# Altium schematic sheet POWER_MUXES.SchDoc
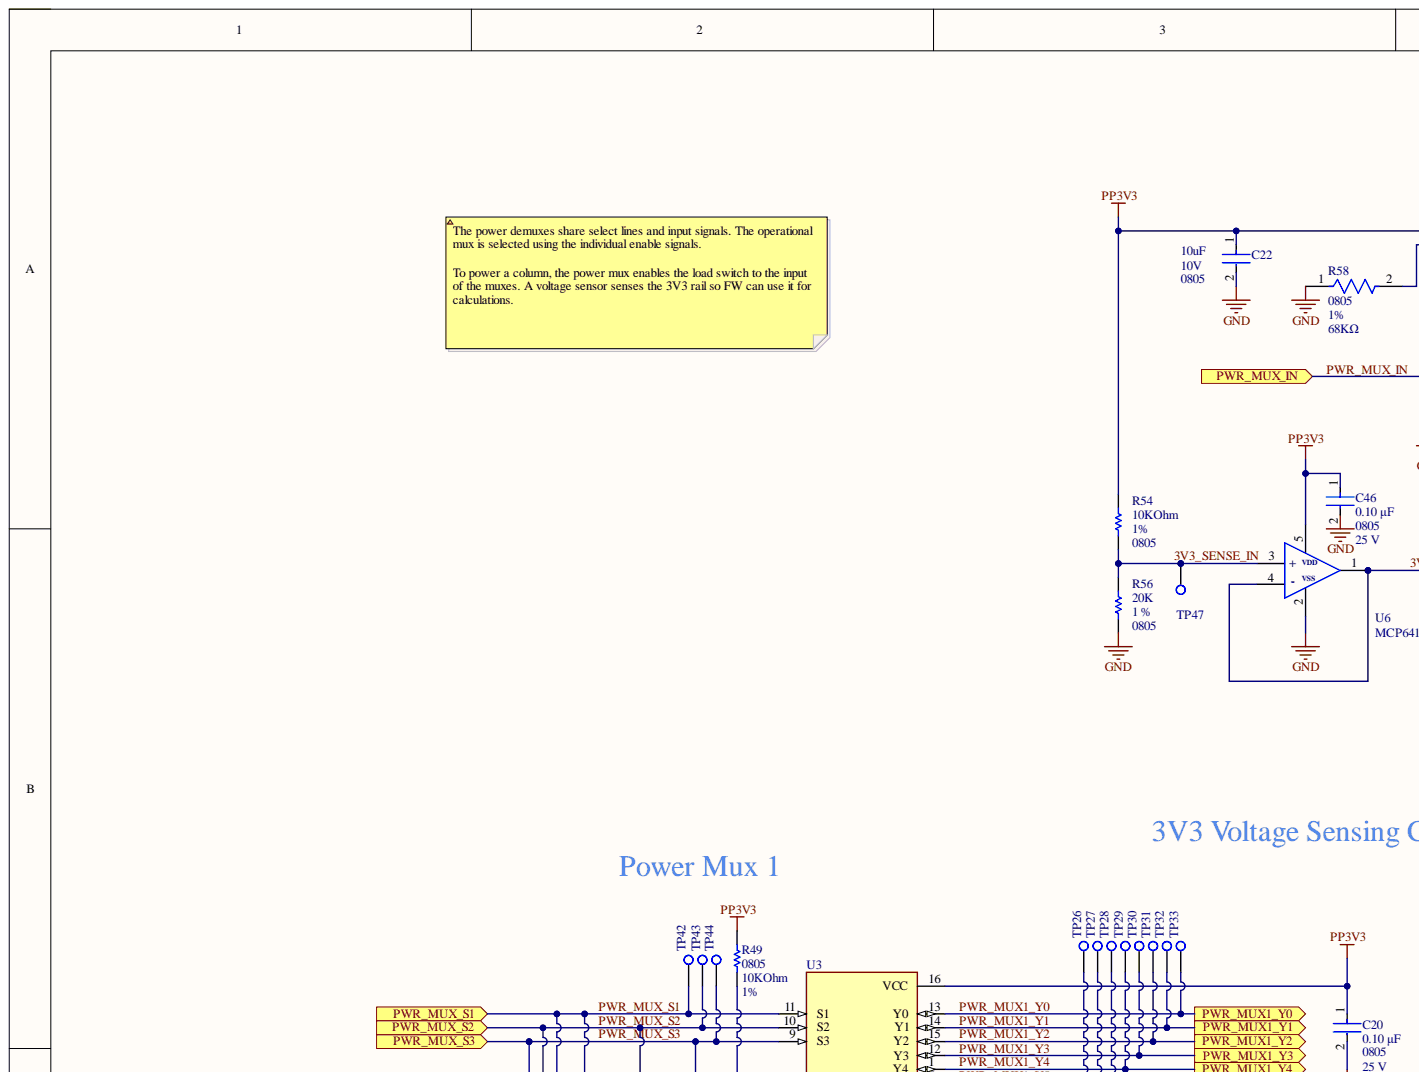
[diagram p0: POWER_MUXES.SchDoc, top left]
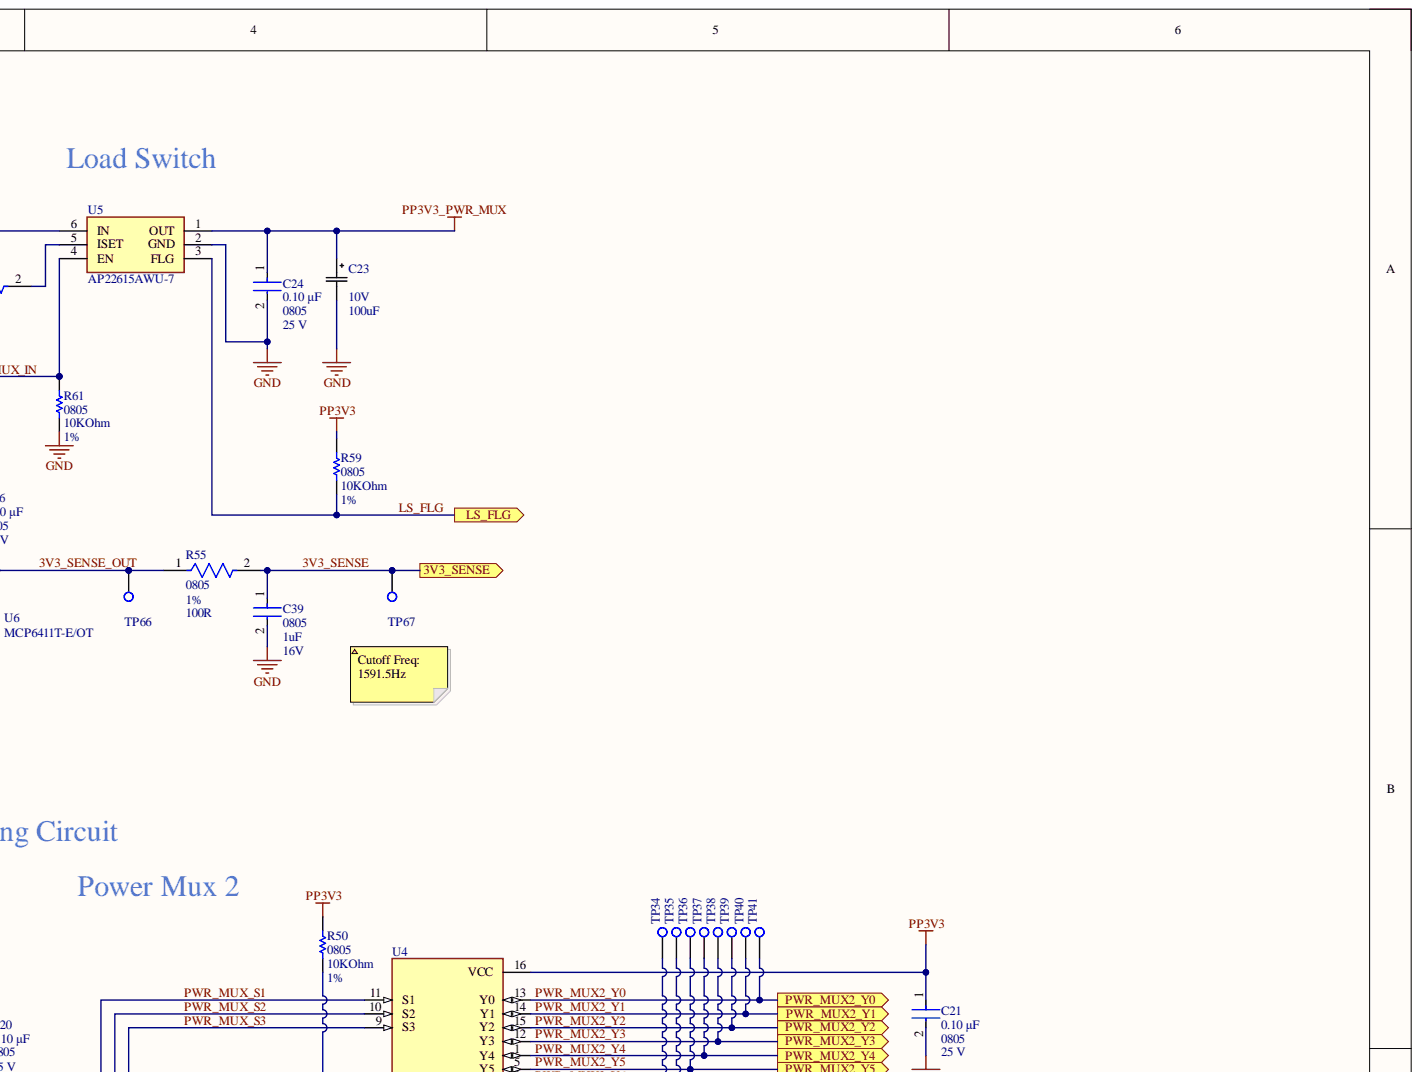
[diagram p1: POWER_MUXES.SchDoc, top right]
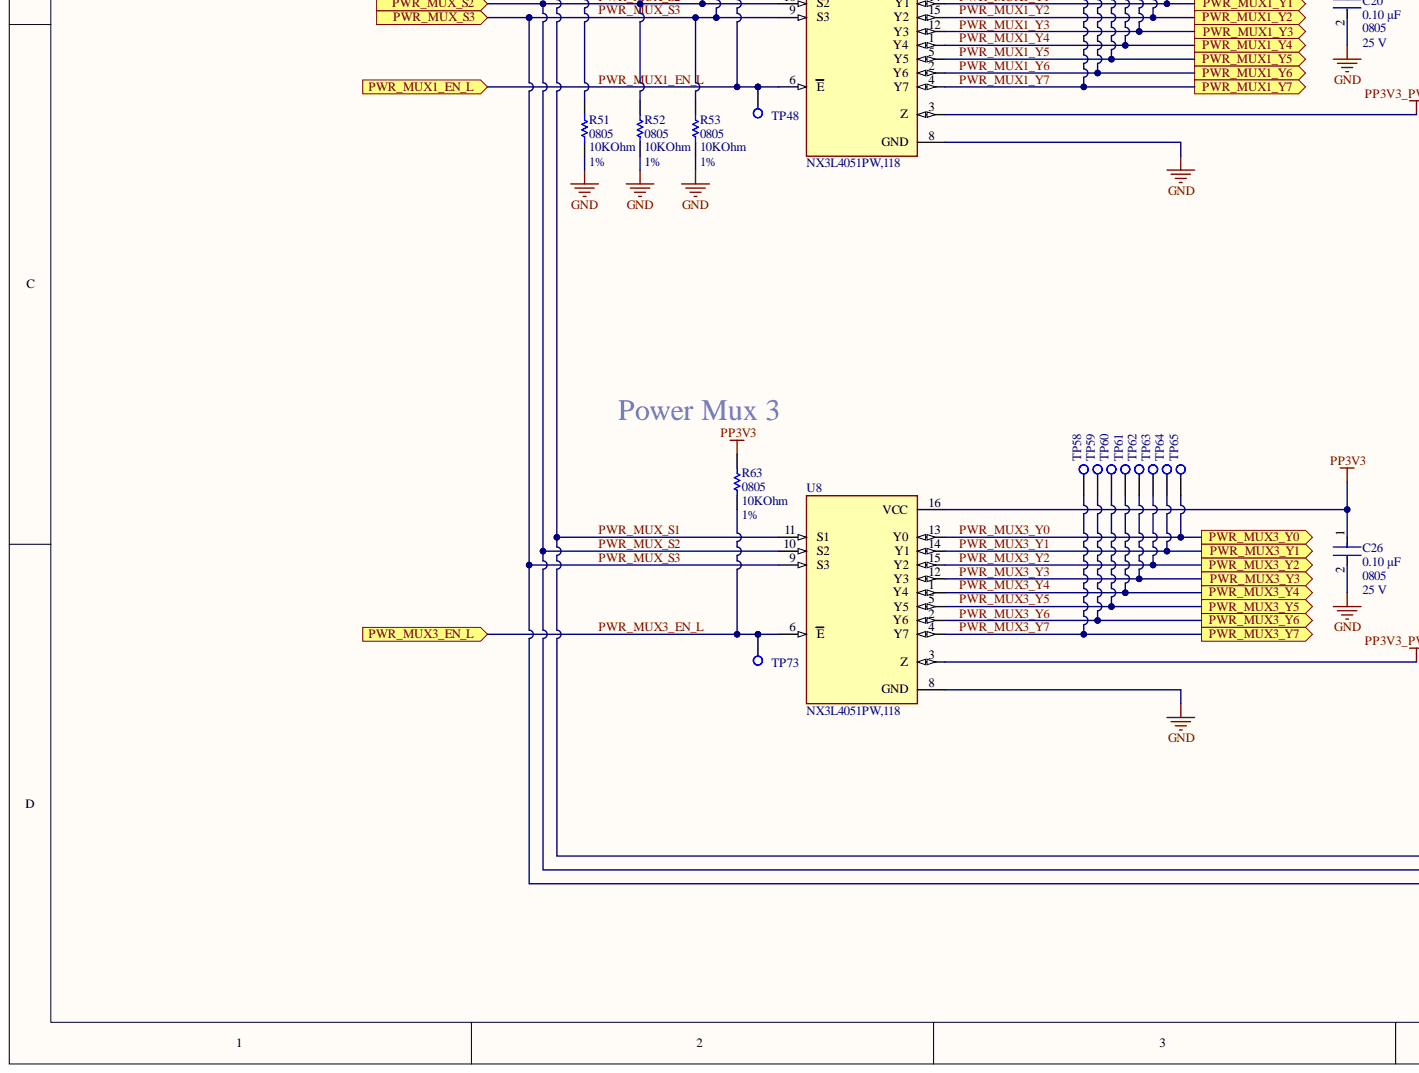
[diagram p2: POWER_MUXES.SchDoc, bottom left]
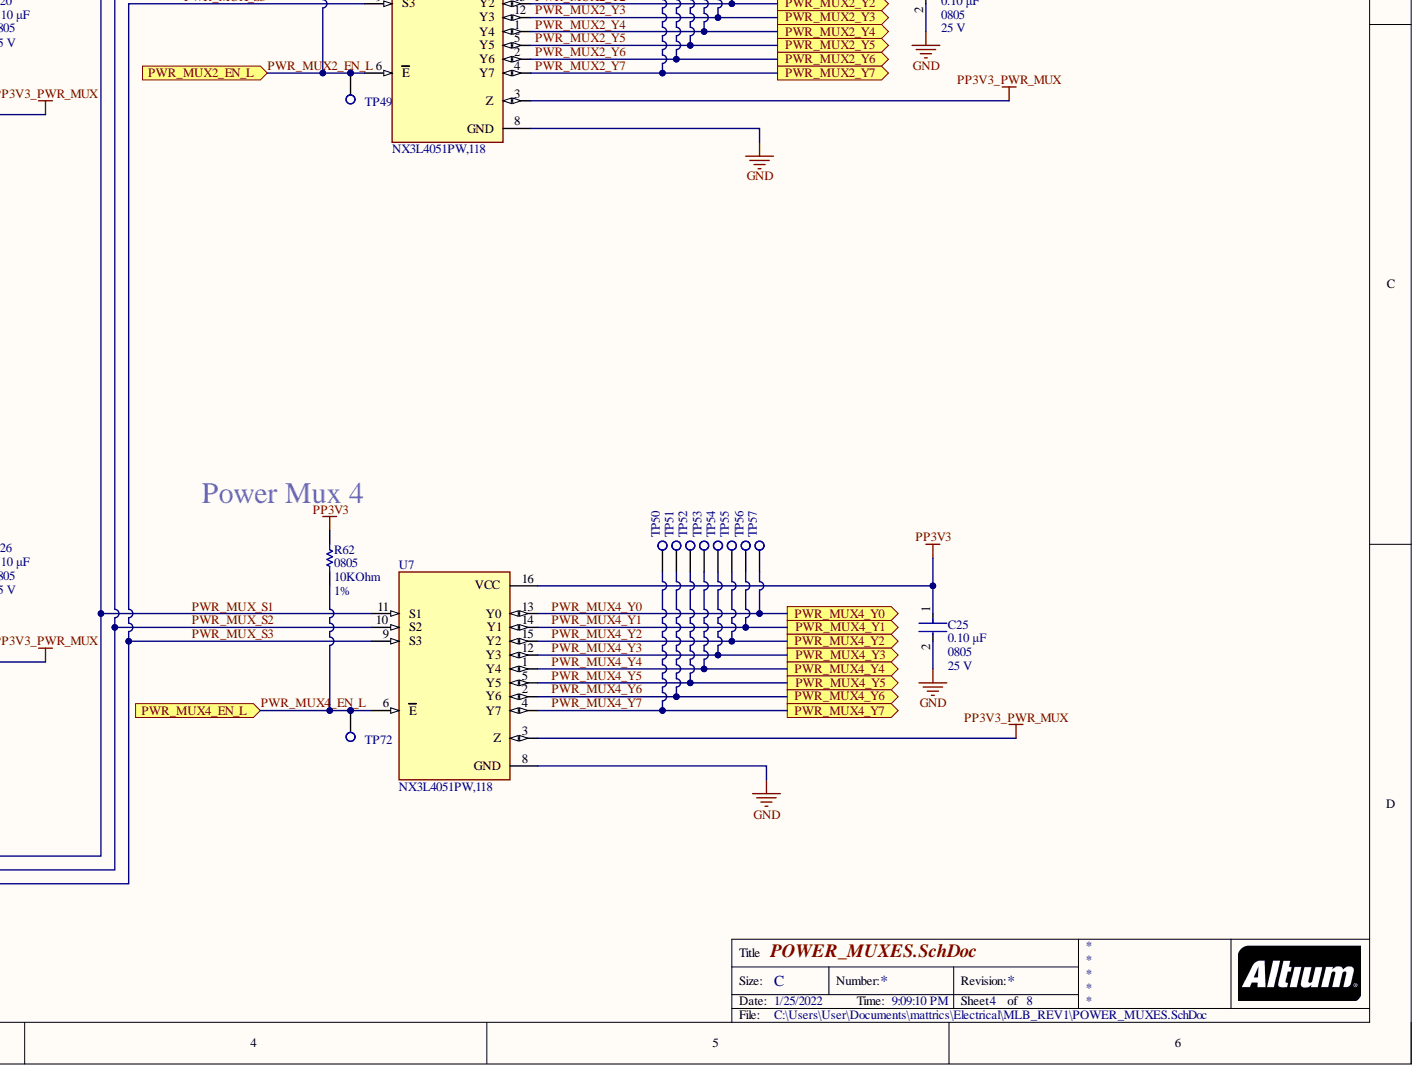
[diagram p3: POWER_MUXES.SchDoc, bottom right]

## Parts
C20  value 0.10 μF  Capacitance: 0.10 μF  Package: 0805  Voltage Rating: 25 V  [FP-C0805C-DN-MFG]  (hidden comment: C0805C104M3RACTU)
C21  value 0.10 μF  Capacitance: 0.10 μF  Package: 0805  Voltage Rating: 25 V  [FP-C0805C-DN-MFG]  (hidden comment: C0805C104M3RACTU)
C22  Capacitance: 10uF  Case/Package: 0805  Voltage Rating (DC): 10V  [FP-GRM21B-0_15-IPC_A]  (hidden comment: GRM21BR71A106KA73K)
C23  Capacitance: 100uF  Voltage: 10V  [CAP_UWF_5X5P4_NCH-M]  (hidden comment: UWR1A101MCL1GB)
C24  value 0.10 μF  Capacitance: 0.10 μF  Package: 0805  Voltage Rating: 25 V  [FP-C0805C-DN-MFG]  (hidden comment: C0805C104M3RACTU)
C25  value 0.10 μF  Capacitance: 0.10 μF  Package: 0805  Voltage Rating: 25 V  [FP-C0805C-DN-MFG]  (hidden comment: C0805C104M3RACTU)
C26  value 0.10 μF  Capacitance: 0.10 μF  Package: 0805  Voltage Rating: 25 V  [FP-C0805C-DN-MFG]  (hidden comment: C0805C104M3RACTU)
C39  Capacitance: 1uF  Case Code (Imperial): 0805  Voltage: 16V  [FP-CL21-IPC_A]  (hidden comment: CL21B105KOFNNNE)
C46  value 0.10 μF  Capacitance: 0.10 μF  Package: 0805  [FP-C0805C-DN-MFG]  (hidden comment: C0805C104M3RACTU)
R49  value 10KOhm  Package Reference: 0805  Tolerance: 1%  [RESC2013X50X30NL20T20]  (hidden comment: CRCW080515K0FKTA)
R50  value 10KOhm  Package Reference: 0805  Tolerance: 1%  [RESC2013X50X30NL20T20]  (hidden comment: CRCW080515K0FKTA)
R51  value 10KOhm  Package Reference: 0805  Tolerance: 1%  [RESC2013X50X30NL20T20]  (hidden comment: CRCW080515K0FKTA)
R52  value 10KOhm  Package Reference: 0805  Tolerance: 1%  [RESC2013X50X30NL20T20]  (hidden comment: CRCW080515K0FKTA)
R53  value 10KOhm  Package Reference: 0805  Tolerance: 1%  [RESC2013X50X30NL20T20]  (hidden comment: CRCW080515K0FKTA)
R54  value 10KOhm  PackageReference: 0805  Tolerance: 1%  [RESC2013X60X35NL10T20]  (hidden comment: RC0805FR-0710KL)
R55  Case Code (Imperial): 0805  Resistance: 100R  Tolerance: 1%  [FP-RMCF0805-IPC_A]  (hidden comment: RMCF0805FT100R)
R56  value 20K  Case-EIA: 0805  Tolerance: 1 %  [RESC0805(2012)_N]  (hidden comment: 20K 1% 0805(2012))
R58  value 68KΩ  Package Reference: 0805  Resistance: 68KΩ  Tolerance: 1%  [FP-CRGCQ0805-IPC_C]  (hidden comment: CRGCQ0805F68K)
R59  value 10KOhm  Package Reference: 0805  Tolerance: 1%  [RESC2013X50X30NL20T20]  (hidden comment: CRCW080515K0FKTA)
R61  value 10KOhm  Package Reference: 0805  Tolerance: 1%  [RESC2013X50X30NL20T20]  (hidden comment: CRCW080515K0FKTA)
R62  value 10KOhm  Package Reference: 0805  Tolerance: 1%  [RESC2013X50X30NL20T20]  (hidden comment: CRCW080515K0FKTA)
R63  value 10KOhm  Package Reference: 0805  Tolerance: 1%  [RESC2013X50X30NL20T20]  (hidden comment: CRCW080515K0FKTA)
TP26  RCU-0C  [TP+195X110]  (value not drawn)
TP27  RCU-0C  [TP+195X110]  (value not drawn)
TP28  RCU-0C  [TP+195X110]  (value not drawn)
TP29  RCU-0C  [TP+195X110]  (value not drawn)
TP30  RCU-0C  [TP+195X110]  (value not drawn)
TP31  RCU-0C  [TP+195X110]  (value not drawn)
TP32  RCU-0C  [TP+195X110]  (value not drawn)
TP33  RCU-0C  [TP+195X110]  (value not drawn)
TP34  RCU-0C  [TP+195X110]  (value not drawn)
TP35  RCU-0C  [TP+195X110]  (value not drawn)
TP36  RCU-0C  [TP+195X110]  (value not drawn)
TP37  RCU-0C  [TP+195X110]  (value not drawn)
TP38  RCU-0C  [TP+195X110]  (value not drawn)
TP39  RCU-0C  [TP+195X110]  (value not drawn)
TP40  RCU-0C  [TP+195X110]  (value not drawn)
TP41  RCU-0C  [TP+195X110]  (value not drawn)
TP42  RCU-0C  [TP+195X110]  (value not drawn)
TP43  RCU-0C  [TP+195X110]  (value not drawn)
TP44  RCU-0C  [TP+195X110]  (value not drawn)
TP47  RCU-0C  [TP+195X110]  (value not drawn)
TP48  RCU-0C  [TP+195X110]  (value not drawn)
TP49  RCU-0C  [TP+195X110]  (value not drawn)
TP50  RCU-0C  [TP+195X110]  (value not drawn)
TP51  RCU-0C  [TP+195X110]  (value not drawn)
TP52  RCU-0C  [TP+195X110]  (value not drawn)
TP53  RCU-0C  [TP+195X110]  (value not drawn)
TP54  RCU-0C  [TP+195X110]  (value not drawn)
TP55  RCU-0C  [TP+195X110]  (value not drawn)
TP56  RCU-0C  [TP+195X110]  (value not drawn)
TP57  RCU-0C  [TP+195X110]  (value not drawn)
TP58  RCU-0C  [TP+195X110]  (value not drawn)
TP59  RCU-0C  [TP+195X110]  (value not drawn)
TP60  RCU-0C  [TP+195X110]  (value not drawn)
TP61  RCU-0C  [TP+195X110]  (value not drawn)
TP62  RCU-0C  [TP+195X110]  (value not drawn)
TP63  RCU-0C  [TP+195X110]  (value not drawn)
TP64  RCU-0C  [TP+195X110]  (value not drawn)
TP65  RCU-0C  [TP+195X110]  (value not drawn)
TP66  RCU-0C  [TP+195X110]  (value not drawn)
TP67  RCU-0C  [TP+195X110]  (value not drawn)
TP72  RCU-0C  [TP+195X110]  (value not drawn)
TP73  RCU-0C  [TP+195X110]  (value not drawn)
U3  NX3L4051PW,118  [SOP65P640X110-16N - duplicate]
U4  NX3L4051PW,118  [SOP65P640X110-16N - duplicate]
U5  AP22615AWU-7  [SOT95P280X100-6N]
U6  MCP6411T-E/OT  [SOT95P280X145-5N]
U7  NX3L4051PW,118  [SOP65P640X110-16N - duplicate]
U8  NX3L4051PW,118  [SOP65P640X110-16N - duplicate]

## Ports
3V3_SENSE, LS_FLG, PWR_MUX1_EN_L, PWR_MUX1_Y0, PWR_MUX1_Y1, PWR_MUX1_Y2, PWR_MUX1_Y3, PWR_MUX1_Y4, PWR_MUX1_Y5, PWR_MUX1_Y6, PWR_MUX1_Y7, PWR_MUX2_EN_L, PWR_MUX2_Y0, PWR_MUX2_Y1, PWR_MUX2_Y2, PWR_MUX2_Y3, PWR_MUX2_Y4, PWR_MUX2_Y5, PWR_MUX2_Y6, PWR_MUX2_Y7, PWR_MUX3_EN_L, PWR_MUX3_Y0, PWR_MUX3_Y1, PWR_MUX3_Y2, PWR_MUX3_Y3, PWR_MUX3_Y4, PWR_MUX3_Y5, PWR_MUX3_Y6, PWR_MUX3_Y7, PWR_MUX4_EN_L, PWR_MUX4_Y0, PWR_MUX4_Y1, PWR_MUX4_Y2, PWR_MUX4_Y3, PWR_MUX4_Y4, PWR_MUX4_Y5, PWR_MUX4_Y6, PWR_MUX4_Y7, PWR_MUX_IN, PWR_MUX_S1, PWR_MUX_S2, PWR_MUX_S3

## Nets
GND: C20.2 C21.2 C22.2 C23.2 C24.2 C25.2 C26.2 C39.2 C46.2 R51.1 R52.1 R53.1 R56.1 R58.1 R61.2 U3.8(GND) U4.8(GND) U5.2(GND) U6.2 U7.8(GND) U8.8(GND)
PP3V3: C20.1 C21.1 C22.1 C25.1 C26.1 C46.1 R49.2 R50.2 R54.2 R59.1 R62.2 R63.2 U3.16(VCC) U4.16(VCC) U5.6(IN) U6.5 U7.16(VCC) U8.16(VCC)
PP3V3_PWR_MUX: C23.1 C24.1 U3.3(Z) U4.3(Z) U5.1(OUT) U7.3(Z) U8.3(Z)
3V3_SENSE: C39.1 R55.2 TP67.1 ; port 3V3_SENSE
3V3_SENSE_IN: R54.1 R56.2 TP47.1 U6.3(+)
3V3_SENSE_OUT: R55.1 TP66.1 U6.1 U6.4(-)
LS_FLG: R59.2 U5.3(FLG) ; port LS_FLG
PWR_MUX1_EN_L: R49.1 TP48.1 U3.6(E) ; port PWR_MUX1_EN_L
PWR_MUX1_Y0: TP33.1 U3.13(Y0) ; port PWR_MUX1_Y0
PWR_MUX1_Y1: TP32.1 U3.14(Y1) ; port PWR_MUX1_Y1
PWR_MUX1_Y2: TP31.1 U3.15(Y2) ; port PWR_MUX1_Y2
PWR_MUX1_Y3: TP30.1 U3.12(Y3) ; port PWR_MUX1_Y3
PWR_MUX1_Y4: TP29.1 U3.1(Y4) ; port PWR_MUX1_Y4
PWR_MUX1_Y5: TP28.1 U3.5(Y5) ; port PWR_MUX1_Y5
PWR_MUX1_Y6: TP27.1 U3.2(Y6) ; port PWR_MUX1_Y6
PWR_MUX1_Y7: TP26.1 U3.4(Y7) ; port PWR_MUX1_Y7
PWR_MUX2_EN_L: R50.1 TP49.1 U4.6(E) ; port PWR_MUX2_EN_L
PWR_MUX2_Y0: TP41.1 U4.13(Y0) ; port PWR_MUX2_Y0
PWR_MUX2_Y1: TP40.1 U4.14(Y1) ; port PWR_MUX2_Y1
PWR_MUX2_Y2: TP39.1 U4.15(Y2) ; port PWR_MUX2_Y2
PWR_MUX2_Y3: TP38.1 U4.12(Y3) ; port PWR_MUX2_Y3
PWR_MUX2_Y4: TP37.1 U4.1(Y4) ; port PWR_MUX2_Y4
PWR_MUX2_Y5: TP36.1 U4.5(Y5) ; port PWR_MUX2_Y5
PWR_MUX2_Y6: TP35.1 U4.2(Y6) ; port PWR_MUX2_Y6
PWR_MUX2_Y7: TP34.1 U4.4(Y7) ; port PWR_MUX2_Y7
PWR_MUX3_EN_L: R63.1 TP73.1 U8.6(E) ; port PWR_MUX3_EN_L
PWR_MUX3_Y0: TP65.1 U8.13(Y0) ; port PWR_MUX3_Y0
PWR_MUX3_Y1: TP64.1 U8.14(Y1) ; port PWR_MUX3_Y1
PWR_MUX3_Y2: TP63.1 U8.15(Y2) ; port PWR_MUX3_Y2
PWR_MUX3_Y3: TP62.1 U8.12(Y3) ; port PWR_MUX3_Y3
PWR_MUX3_Y4: TP61.1 U8.1(Y4) ; port PWR_MUX3_Y4
PWR_MUX3_Y5: TP60.1 U8.5(Y5) ; port PWR_MUX3_Y5
PWR_MUX3_Y6: TP59.1 U8.2(Y6) ; port PWR_MUX3_Y6
PWR_MUX3_Y7: TP58.1 U8.4(Y7) ; port PWR_MUX3_Y7
PWR_MUX4_EN_L: R62.1 TP72.1 U7.6(E) ; port PWR_MUX4_EN_L
PWR_MUX4_Y0: TP57.1 U7.13(Y0) ; port PWR_MUX4_Y0
PWR_MUX4_Y1: TP56.1 U7.14(Y1) ; port PWR_MUX4_Y1
PWR_MUX4_Y2: TP55.1 U7.15(Y2) ; port PWR_MUX4_Y2
PWR_MUX4_Y3: TP54.1 U7.12(Y3) ; port PWR_MUX4_Y3
PWR_MUX4_Y4: TP53.1 U7.1(Y4) ; port PWR_MUX4_Y4
PWR_MUX4_Y5: TP52.1 U7.5(Y5) ; port PWR_MUX4_Y5
PWR_MUX4_Y6: TP51.1 U7.2(Y6) ; port PWR_MUX4_Y6
PWR_MUX4_Y7: TP50.1 U7.4(Y7) ; port PWR_MUX4_Y7
PWR_MUX_IN: R61.1 U5.4(EN) ; port PWR_MUX_IN
PWR_MUX_S1: R51.2 TP42.1 U3.11(S1) U4.11(S1) U7.11(S1) U8.11(S1) ; port PWR_MUX_S1
PWR_MUX_S2: R52.2 TP43.1 U3.10(S2) U4.10(S2) U7.10(S2) U8.10(S2) ; port PWR_MUX_S2
PWR_MUX_S3: R53.2 TP44.1 U3.9(S3) U4.9(S3) U7.9(S3) U8.9(S3) ; port PWR_MUX_S3
NetR58_2: R58.2 U5.5(ISET)

## Library data of the parts
C20 C21 C24-C26 C46 = CMP-2007-00282-2: CAP CER 0.1UF 25V 20% X7R 0805; Manufacturer: KEMET; Material: Ceramic; Temperature Coefficient: 30ppm/°C; Tolerance: 0.2; Automotive: No; Category: Cap; Component Kind: Standard; ComponentLink2Description: Datasheet; ComponentLink2URL: https://content.kemet.com/datasheets/KEM_C1003_C0G_SMD.pdf; Device Class L1: Passive Components; Device Class L2: Capacitors; Device Class L3: Ceramic Capacitors; Digikey Description: CAP CER 0.1UF 25V 20% X7R 0805; Height: 0.88mm; Ipc Land Pattern Name: CAPC200125X78; Lead Free: Yes; Library Name: Altium Content Vault; Manufacturer Part Number: C0805C104M3RACTU; Mouser Description: Multilayer Ceramic Capacitors MLCC - SMD/SMT 25volts 0.1uF X7R 20%; Octopart: https://octopart.com/c0805c104m3ractu-kemet-9138612; RoHS: TRUE; Supplier: Digi-Key; Supplier Part Number: 399-C0805C104M3RAC7800CT-ND; Temperature: -55°C to +125°C; Temperature Characteristic: X7R; Temperature Range High: +125°C; Temperature Range Low: -55°C
  C46: Voltage Rating: 25 V
C22 = CMP-06035-038134-1: Chip Multilayer Ceramic Capacitors for General Purpose, 0805, 10uF, X7R, 15%, 10%, 10V; Manufacturer: Murata Electronics; Component Kind: Standard; Dielectric: X7R; Length: 2mm; Library Name: Altium Content Vault; Manufacturer Part Number: GRM21BR71A106KA73K; Supplier: Digi-Key; Supplier Part Number: 490-14381-1-ND; Thickness: 1.25mm; Tolerance: 10%; Width: 1.25mm
C23 = UWR1A101MCL1GB: No Description Available; Manufacturer: Nichicon; Type: DEV; Component Kind: Standard; Digi-Key_Part_Number_1: 493-14561-1-ND; Digi-Key_Part_Number_2: 493-14561-2-ND; Digi-Key_Part_Number_3: 493-14561-6-ND; Library Name: MLBSchLib.SchLib; Manufacturer Part Number: UWR1A101MCL1GB; RefDes: RefDes; Supplier: Digi-Key; Supplier Part Number: 493-14561-1-ND; Copyright: Copyright (C) 2022 Ultra Librarian. All rights reserved.
C39 = CMP-13271-003098-1: CL21 Series 0805 1uF 16V ±10% Tolerance X7R Multilayer Ceramic Chip Capacitor; Manufacturer: Samsung Electro-Mechanics; Case Code (Metric): 2012; Case/Package: 0805; Component Kind: Standard; Contact Plating: Tin; Depth: 1.25mm; Dielectric: X7R; Height: 1.25mm; Lead Free: Lead Free; Length: 2mm; Library Name: MLBSchLib.SchLib; Manufacturer Part Number: CL21B105KOFNNNE; Max Operating Temperature: 125°C; Min Operating Temperature: -55°C; Mount: Surface Mount; Package Quantity: 1; Packaging: Tape and Reel; Radiation Hardening: No; Supplier: Digi-Key; Supplier Part Number: 1276-1026-1-ND; Thickness: 0.053inch; Tolerance: 10%; Voltage Rating: 16V; Voltage Rating (DC): 16V; Width: 0.049inch
R49-R53 R59 R61-R63 = CMP-2001-04968-1: Manufacturer: Vishay Dale; Component Kind: Standard; Library Name: Altium Content Vault; Manufacturer Part Number: CRCW080515K0FKTA; Supplier: Digi-Key; Supplier Part Number: 541-3019-1-ND
R54 = CMP-1659-00013-4: Chip Resistor, 10 KOhm, +/- 1%, 0.125 W, -55 to 155 degC, 0805 (2012 Metric), RoHS, Tape and Reel; Manufacturer: Yageo; Voltage Rating: 150 V; Component Kind: Standard; ComponentLink1Description: Manufacturer URL; ComponentLink1URL: http://www.yageo.com/; ComponentLink2Description: Datasheet; ComponentLink2URL: http://www.yageo.com/documents/recent/PYu-RC_Group_51_RoHS_L_04.pdf; DatasheetVersion: Rev. 4, 02/2016; Height: 0.5 mm; Length: 2 mm; Library Name: Altium Content Vault; Manufacturer Part Number: RC0805FR-0710KL; Max Operating Temperature: 155 degC; Min Operating Temperature: -55 degC; Mount: Surface Mount; Mounting Technology: Surface Mount; Package Quantity: 5000; PackageDescription: 2-Pin Surface Mounted Device, Body 2 x 1.25 mm; Packaging: Tape and Reel; Pins: 2; REACH SVHC: No SVHC; RoHSCompliant: true; Supplier: Digi-Key; Supplier Part Number: RC0805FR-0710KL
R55 = CMP-26527-001731-1: 100Ω ±1% 0.125W 0805 Thick Film Chip Resistor AEC-Q200 compliant; Manufacturer: Stackpole Electronics Inc; Max Power Dissipation: 125mW; Power Rating: 250mW; Temperature Coefficient: 100ppm/°C; Case Code (Metric): 2012; Case/Package: 0805; Component Kind: Standard; Construction: Rectangular; Contact Plating: Tin; Depth: 1.25mm; Features: Automotive AEC-Q200; Height: 0.026inch; Lead Free: Lead Free; Length: 2mm; Library Name: Altium Content Vault; Manufacturer Part Number: RMCF0805FT100R; Max Operating Temperature: 155°C; Military Standard: Not; Min Operating Temperature: -55°C; Mount: Surface Mount; Number of Terminations: 2; Packaging: Tape and Reel; Radiation Hardening: No; Resistor Type: Thick Film; RoHS Compliant: Yes; Series: RMCF; Size Code: 0805; Supplier: Digi-Key; Supplier Part Number: RMCF0805FT100RCT-ND; Width: 0.049inch
R56 = CMP-1013-00655-1: 20K 0.125W 1% 0805 (2012 Metric)  SMD; Manufacturer: YAGEO; Manufacturer Part Number: RC0805FR-0720KL; Case-Metric: 2012; Component Kind: Standard; Library Name: Altium Content Vault; Power: 0.125 W; Supplier: Digi-Key; Supplier Part Number: 311-20.0KCRCT-ND; Technology: SMT
R58 = CMP-03211-004185-1: CRGCQ0805 68KΩ 0.125W ±100ppm/°C 1% 150V; Manufacturer: TE Connectivity Passive Product; Ambient Temperature Range High: +70°C; Automotive: Yes; Automotive Grade: Grade 1103; Category: Res; Component Kind: Standard; ComponentLink2Description: Datasheet; ComponentLink2URL: https://www.te.com/commerce/DocumentDelivery/DDEController?Action=showdoc&DocId=Data+Sheet%7F1773204-3%7F1%7Fpdf%7FEnglish%7FENG_DS_1773204-3_1.pdf%7F4-2176341-1112; Composition: Thick Film; Device Class L1: Passive Components; Device Class L2: Resistors; Device Class L3: Chip SMD Resistors; Height: 0.65mm; Ipc Land Pattern Name: RESC200125X55; Lead Free: Yes; Library Name: MLBSchLib.SchLib; Manufacturer Part Number: CRGCQ0805F68K; Octopart: https://octopart.com/crgcq0805f68k-te+connectivity-91018191; Package: CRGCQ0805; Power Rating: 0.125W; RoHS: TRUE; Series: CRGCQ; Supplier: Digi-Key; Supplier Part Number: A129771CT-ND; Temperature: -55°C to +155°C; Temperature Coefficient: ±100ppm/°C; Temperature Range High: +155°C; Temperature Range Low: -55°C; Voltage Rating: 150V
TP26-TP44 TP47-TP67 TP72 TP73 = RCU-0C: Natural PC Test Point , Plating Surface Mount Mounting Type; Manufacturer: TE Connectivity AMP Connectors; Check_prices: https://www.snapeda.com/parts/RCU-0C/TE%20Connectivity/view-part/4791537/?ref=eda; Component Kind: Standard; Library Name: MLBSchLib.SchLib; Manufacturer Part Number: RCU-0C; Package: SON-6 TE Connectivity AMP Connectors; Purchase-URL: https://pricing.snapeda.com/search/part/RCU-0C/?ref=eda; SnapEDA_Link: https://www.snapeda.com/parts/RCU-0C/TE%20Connectivity/view-part/4791537/?ref=snap; Supplier: Digi-Key; Supplier Part Number: A106145CT-ND
U3 U4 U7 U8 = NX3L4051PW,118: 1 Circuit IC Switch 8:1 750mOhm 16-TSSOP; Manufacturer: NXP USA Inc.; MF: NXP USA; Check_prices: https://www.snapeda.com/parts/NX3L4051PW,118/NXP%20USA%20Inc./view-part/648693/?ref=eda; Component Kind: Standard; Digi-Key_Part_Number: 568-12393-1-ND; Library Name: MLBSchLib.SchLib; Manufacturer Part Number: NX3L4051PW,118; MP: NX3L4051PW,118; Package: TSSOP-16 NXP USA; Purchase-URL: https://pricing.snapeda.com/search/part/NX3L4051PW,118/?ref=eda; SnapEDA_Link: https://www.snapeda.com/parts/NX3L4051PW,118/NXP%20USA%20Inc./view-part/648693/?ref=snap; Supplier: Digi-Key; Supplier Part Number: 568-12393-1-ND
U5 = AP22615AWU-7: Integrated Circuit; Manufacturer: Diodes Inc.; Arrow Part Number: AP22615AWU-7; Arrow Price/Stock: https://www.arrow.com/en/products/ap22615awu-7/diodes-incorporated; Component Kind: Standard; Datasheet Link: https://www.diodes.com/assets/Datasheets/AP22815-615.pdf; Height: 1mm; Library Name: MLBSchLib.SchLib; Manufacturer Part Number: AP22615AWU-7; Supplier: Digi-Key; Supplier Part Number: AP22615AWU-7DICT-ND
U6 = MCP6411T-E_OT: IC OPAMP GP 1 CIRCUIT SOT23-5; Manufacturer: Microchip; Arrow Part Number: MCP6411T-E/OT; Arrow Price/Stock: https://www.arrow.com/en/products/mcp6411t-eot/microchip-technology; Component Kind: Standard; Datasheet Link: http://ww1.microchip.com/downloads/en/DeviceDoc/20005791B.pdf; Height: 1.45mm; Library Name: MLBSchLib.SchLib; Manufacturer Part Number: MCP6411T-E/OT; Mouser Part Number: 579-MCP6411T-E/OT; Mouser Price/Stock: https://www.mouser.com/Search/Refine.aspx?Keyword=579-MCP6411T-E%2FOT; Supplier: Digi-Key; Supplier Part Number: MCP6411T-E/OTCT-ND
